# Altium schematic sheet MCU_SUPPORT_ZS.SchDoc
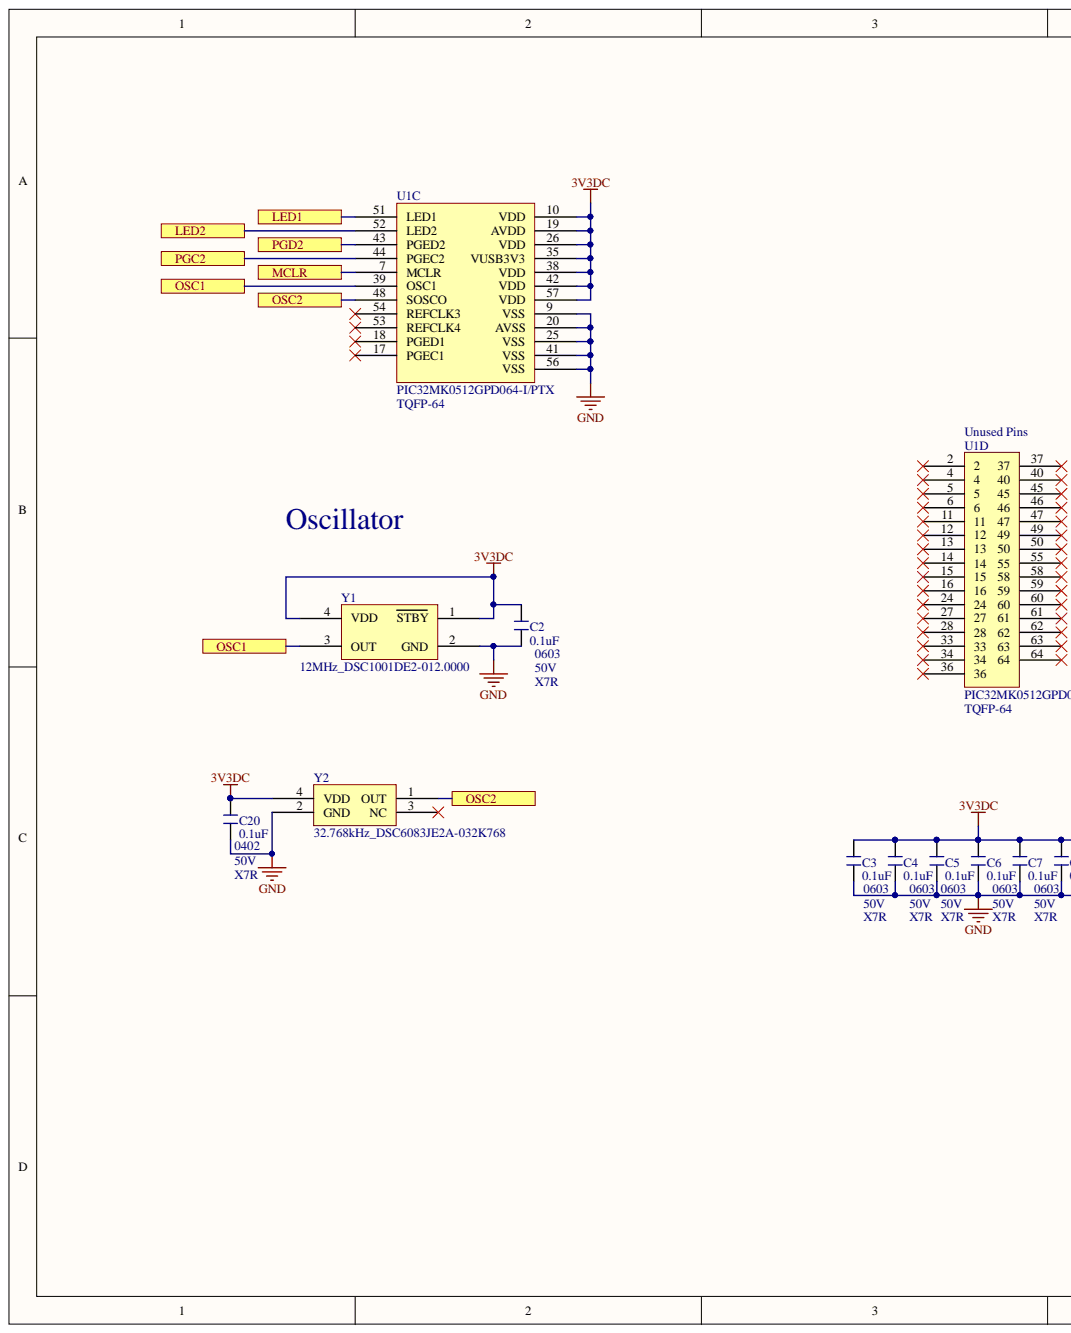
[diagram p0: MCU_SUPPORT_ZS.SchDoc, left]
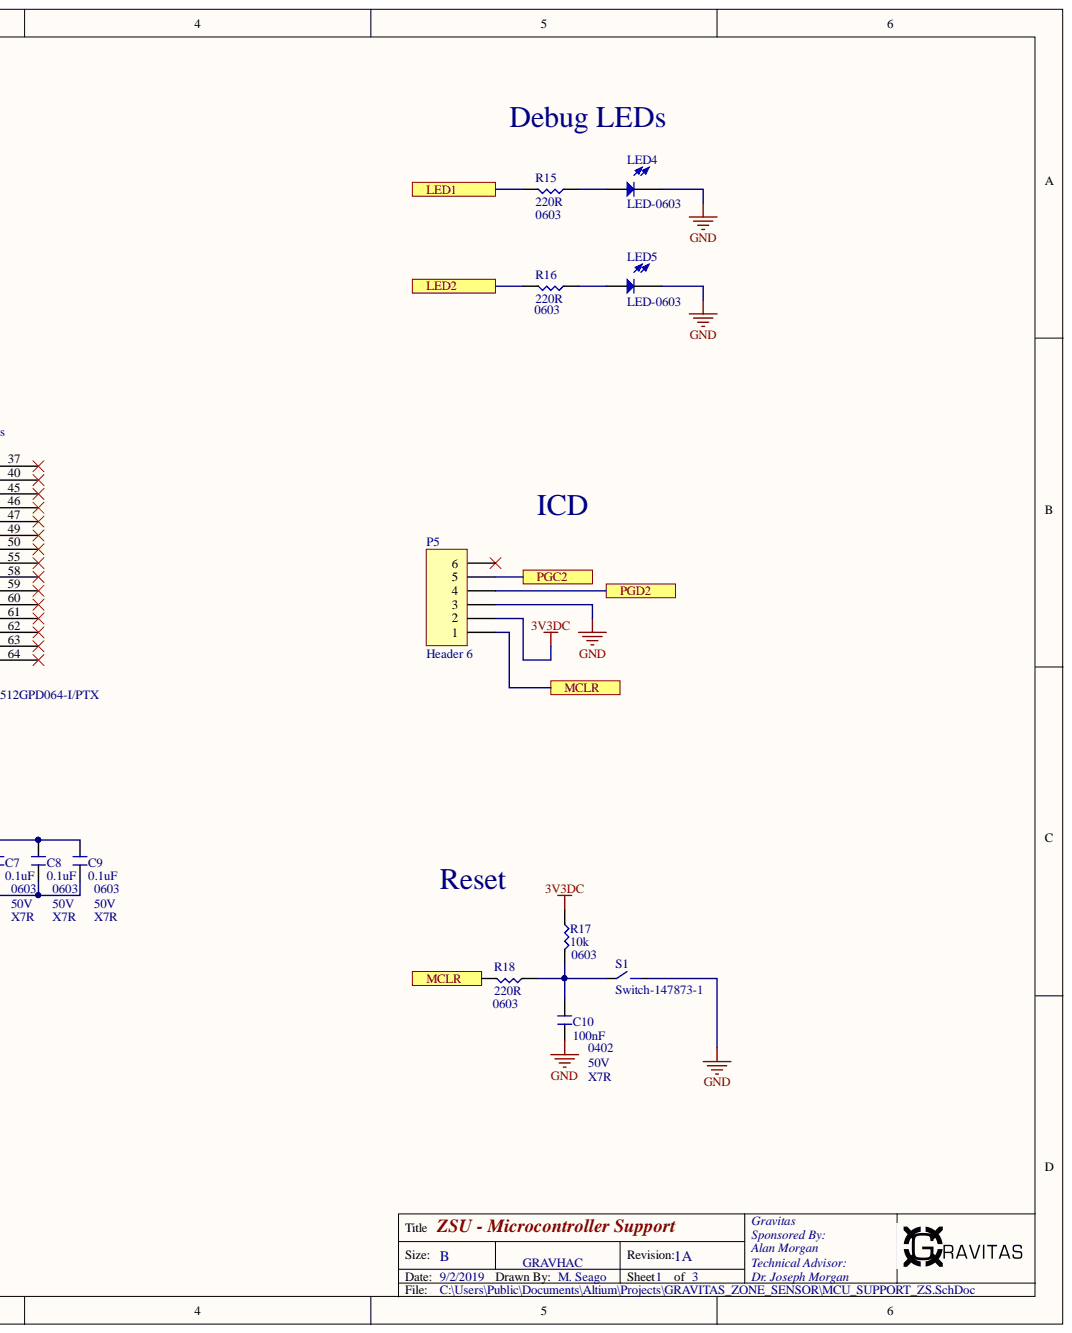
[diagram p1: MCU_SUPPORT_ZS.SchDoc, right]

## Parts
C2  0.1uF  [NonPolar-0603]
C3  0.1uF  [NonPolar-0603]
C4  0.1uF  [NonPolar-0603]
C5  0.1uF  [NonPolar-0603]
C6  0.1uF  [NonPolar-0603]
C7  0.1uF  [NonPolar-0603]
C8  0.1uF  [NonPolar-0603]
C9  0.1uF  [NonPolar-0603]
C10  100nF  [NonPolar-0402]
C20  0.1uF  [NonPolar-0402]
LED4  LED-0603  [LED-0603]
LED5  LED-0603  [LED-0603]
P5  Header 6  [HDR1X6]
R15  220R  [NonPolar-0603]
R16  220R  [NonPolar-0603]
R17  10k  [NonPolar-0603]
R18  220R  [NonPolar-0603]
S1  Switch-147873-1  [Switch - 147873-1]
U1  PIC32MK0512GPD064-I/PTX  [V2_PIC32MK0512GPD064IPT]  (value not drawn)  (parts C,D)
Y1  12MHz_DSC1001DE2-012.0000  [12MHz_DSC1001DE2-012.0000]
Y2  32.768kHz_DSC6083JE2A-032K768  [32.768kHz_DSC6083JE2A-032K768]

## Ports
LED1, LED2, MCLR, OSC1, OSC2, PGC2, PGD2

## Nets
3V3DC: C2.2 C3.2 C4.2 C5.2 C6.2 C7.2 C8.2 C9.2 C20.2 P5.2 R17.2 U1.10(VDD) U1.19(AVDD) U1.26(VDD) U1.35(VUSB3V3) U1.38(VDD) U1.42(VDD) U1.57(VDD) Y1.1(STBY) Y1.4(VDD) Y2.4(VDD)
GND: C2.1 C3.1 C4.1 C5.1 C6.1 C7.1 C8.1 C9.1 C10.1 C20.1 LED4.2 LED5.2 P5.3 S1.2 U1.9(VSS) U1.20(AVSS) U1.25(VSS) U1.41(VSS) U1.56(VSS) Y1.2(GND) Y2.2(GND)
LED1: R15.1 U1.51(LED1) ; port LED1
LED2: R16.1 U1.52(LED2) ; port LED2
MCLR: P5.1 R18.1 U1.7(MCLR) ; port MCLR
OSC1: U1.39(OSC1) Y1.3(OUT) ; port OSC1
OSC2: U1.48(SOSCO) Y2.1(OUT) ; port OSC2
PGC2: P5.5 U1.44(PGEC2) ; port PGC2
PGD2: P5.4 U1.43(PGED2) ; port PGD2
NetC10_2: C10.2 R17.1 R18.2 S1.1
NetLED4_1: LED4.1 R15.2
NetLED5_1: LED5.1 R16.2

Not connected: P5 6; U1 2 4 5 6 11 12 13 14 15 16 17(PGEC1) 18(PGED1) 24 27 28 33 34 36 37 40 45 46 47 49 50 53(REFCLK4) 54(REFCLK3) 55 58 59 60 61 62 63 64; Y2 3(NC)

## Library data of the parts
C2-C9 = Cap - 0603: Component Kind: Standard; Library Name: GravLib.IntLib
C10 C20 = Cap - 0402: Component Kind: Standard; Library Name: GravLib.IntLib
P5 = Header 6: Header, 6-Pin; Component Kind: Standard; LatestRevisionDate: 17-Jul-2002; LatestRevisionNote: Re-released for DXP Platform.; Library Name: Miscellaneous Connectors.IntLib; Signal Integrity: Connector; Publisher: Altium Limited
R15-R18 = Res - 0603: Component Kind: Standard; Library Name: GravLib.IntLib
LED4 LED5 = LED-0603: Component Kind: Standard; Library Name: GravLib.IntLib
S1 = Switch-147873-1: Component Kind: Standard; Library Name: GravLib.IntLib
U1 = PIC32MK0512GPD064-I/PTX: Component Kind: Standard; Library Name: GravLib.IntLib
Y1 = 12MHz_DSC1001DE2-012.0000: Component Kind: Standard; Library Name: GravLib.IntLib
Y2 = 32.768kHz_DSC6083JE2A-032K768: Component Kind: Standard; Library Name: GravLib.IntLib
